# Altium schematic sheet Trinamic Driver Schematic.SchDoc
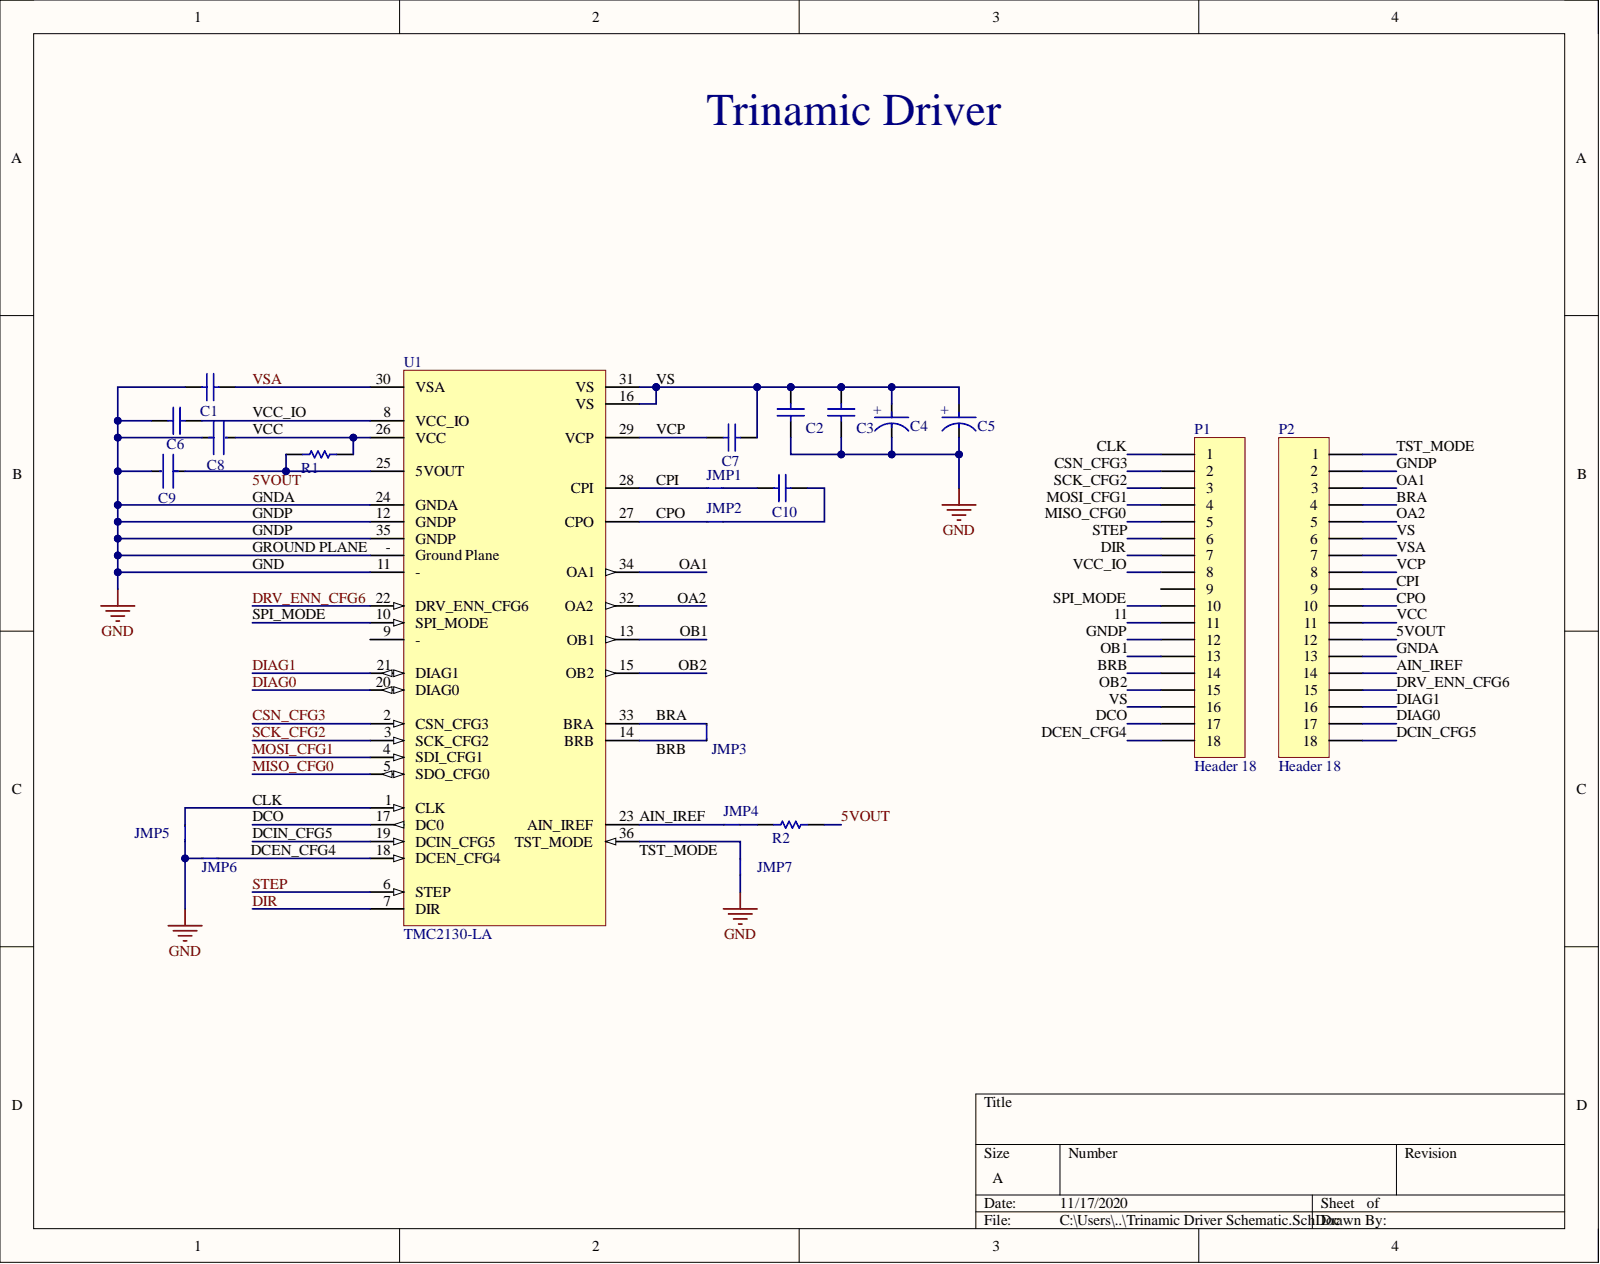
[diagram p0: Trinamic Driver Schematic.SchDoc]

## Parts
C1  CGA3E2X7R1H104K080AA  [CAPC1608X90X20LL10]  (value not drawn)
C2  CGA3E2X7R1H104K080AA  [CAPC1608X90X20LL10]  (value not drawn)
C3  C1210C476M4PACTU  [CAPC3225X270X50LL20T25]  (value not drawn)
C4  EEE-FK1H101P  [FP-EEE-FK1H101P-MFG]  (value not drawn)
C5  EEE-FK1H101P  [FP-EEE-FK1H101P-MFG]  (value not drawn)
C6  CGA3E2X7R1H104K080AA  [CAPC1608X90X20LL10]  (value not drawn)
C7  CGA3E2X7R1H104K080AA  [CAPC1608X90X20LL10]  (value not drawn)
C8  C0603C474K4RACTU  [FP-C0603C-CF-MFG]  (value not drawn)
C9  C0603C475K9PACTU  [FP-C0603-CG-MFG]  (value not drawn)
C10  CC0603KRX7R9BB223  [CAPC1608X90X40ML10T20]  (value not drawn)
JMP1  Solder Jump  [Solder Jump]  (value not drawn)
JMP2  Solder Jump  [Solder Jump]  (value not drawn)
JMP3  Solder Jump  [Solder Jump]  (value not drawn)
JMP4  Solder Jump  [Solder Jump]  (value not drawn)
JMP5  Solder Jump  [Solder Jump]  (value not drawn)
JMP6  Solder Jump  [Solder Jump]  (value not drawn)
JMP7  Solder Jump  [Solder Jump]  (value not drawn)
P1  Header 18  [HDR1X18]
P2  Header 18  [HDR1X18]
R1  CRCW06033R30JNEA  [RESC1609X50X30ML10T20]  (value not drawn)
R2  ERJ3EKF1002V  [RESC1609X55X30LL15T15]  (value not drawn)
U1  TMC2130-LA  [QFN-36]

## Nets
5VOUT: C9.1 P2.12 R1.1 R2.2 U1.25(5VOUT)
11: P1.11
AIN_IREF: JMP4.1 P2.14 U1.23(AIN_IREF)
BRA: JMP3.2 P2.4 U1.33(BRA)
BRB: JMP3.1 P1.14 U1.14(BRB)
CLK: JMP5.2 P1.1 U1.1(CLK)
CPI: JMP1.1 P2.9 U1.28(CPI)
CPO: JMP2.1 P2.10 U1.27(CPO)
CSN_CFG3: P1.2 U1.2(CSN_CFG3)
DCEN_CFG4: JMP6.1 P1.18 U1.18(DCEN_CFG4)
DCIN_CFG5: P2.18 U1.19(DCIN_CFG5)
DCO: P1.17 U1.17(DC0)
DIAG0: P2.17 U1.20(DIAG0)
DIAG1: P2.16 U1.21(DIAG1)
DIR: P1.7 U1.7(DIR)
DRV_ENN_CFG6: P2.15 U1.22(DRV_ENN_CFG6)
GND: C1.1 C2.1 C3.1 C4.1 C5.1 C6.1 C8.1 C9.2 JMP5.1 JMP6.2 JMP7.2 P1.12 P2.2 P2.13 U1.11(-) U1.12(GNDP) U1.24(GNDA) U1.35(GNDP) U1.-(Ground_Plane)
MISO_CFG0: P1.5 U1.5(SDO_CFG0)
MOSI_CFG1: P1.4 U1.4(SDI_CFG1)
OA1: P2.3 U1.34(OA1)
OA2: P2.5 U1.32(OA2)
OB1: P1.13 U1.13(OB1)
OB2: P1.15 U1.15(OB2)
SCK_CFG2: P1.3 U1.3(SCK_CFG2)
SPI_MODE: P1.10 U1.10(SPI_MODE)
STEP: P1.6 U1.6(STEP)
TST_MODE: JMP7.1 P2.1 U1.36(TST_MODE)
VCC: C8.2 P2.11 R1.2 U1.26(VCC)
VCC_IO: C6.2 P1.8 U1.8(VCC_IO)
VCP: C7.2 P2.8 U1.29(VCP)
VS: C2.2 C3.2 C4.2 C5.2 C7.1 P1.16 P2.6 U1.16(VS) U1.31(VS)
VSA: C1.2 P2.7 U1.30(VSA)
NetC10_1: C10.1 JMP1.2
NetC10_2: C10.2 JMP2.2
NetJMP4_2: JMP4.2 R2.1

Not connected: P1 9; U1 9(-)

## Library data of the parts
C1 C2 C6 C7 = CMP-2000-07546-1: Chip Capacitor, 0.1 uF, +/- 10%, 50 V, -55 to 125 degC, 0603 (1608 Metric), RoHS, Tape and Reel; Manufacturer: TDK; Capacitance: 100nF; Dielectric: X7R; Voltage: 50V; Case Code (Imperial): 0603; Case Code (Metric): 1608; Case/Package: 0603; Component Kind: Standard; Composition: Ceramic; Datasheet URL: https://product.tdk.com/info/en/catalog/datasheets/mlcc_automotive_general_en.pdf; Datasheet Version: 12/2014; Depth: 0.8mm; Height: 0.8mm; Lead Free: LeadFree; Length: 1.6mm; Library Name: Altium Content Vault; Manufacturer URL: http://www.tdk.com/; Max Operating Temperature: 125degC; Min Operating Temperature: -55degC; Mount: SurfaceMount; Mounting Technology: SurfaceMount; Package Description: 2-Pin Surface Mount Device, Body 1.6 x 0.8 mm; Package Quantity: 1; Package Reference: 603; Packaging: TapeandReel; Radiation Hardening: No; REACH SVHC: NoSVHC; RoHSCompliant: True; Termination: SMD/SMT; Thickness: 0.035inch; Tolerance: 10%; Voltage Rating: 50V; Voltage Rating (DC): 50V; Weight: 7.1E-05oz; Width: 0.8mm
C3 = CMP-2000-06020-1: Chip Capacitor, 47 uF, +/- 20%, 16 V, -55 to 85 degC, 1210 (3225 Metric), RoHS, Tape and Reel; Manufacturer: Kemet; Capacitance: 47µF; Catalog Drawings: ACTU_1210; Online Catalog: X5RDielectric(CommercialGrade); Other Names: 399-5514-6; Product Training Modules: CapacitorBasics-TypicalUsesforCapacitors; Temperature Coefficient: X5R; Voltage - Rated: 16V; Applications: GeneralPurpose; Category: Capacitors; Component Kind: Standard; Datasheet URL: http://www.datasheets360.com/pdf/-8690541728068054270; Datasheet Version: 05/2013; Family: CeramicCapacitors; Features: -; Height - Seated (Max): -; Lead Spacing: -; Lead Style: -; Library Name: Altium Content Vault; Manufacturer URL: http://www.kemet.com/; Mounting Technology: SurfaceMount; Mounting Type: SurfaceMount,MLCC; Operating Temperature: -55°C~85°C; Package / Case: 1210(3225Metric); Package Description: 2-Pin Surface Mount Device, Body 3.2 x 2.5 mm; Package Reference: 1210; Packaging: Digi-Reel®; Ratings: -; Series: C; Size / Dimension: 0.126"Lx0.098"W(3.20mmx2.50mm); Standard Package: 1; Thickness (Max): 0.110"(2.80mm); Tolerance: ±20%
C4 C5 = CMP-05427-000005-1: CAP ALUM 100UF 20% 50V SMD; Manufacturer: Panasonic Electronic Components; Automotive Grade: Grade 2; Dissipation Factor: 10%; Esr: 0.34Ω; Leakage Current: 50uA; Life: 2000Hours; Material: Aluminum; Ripple Current: 350mA; Automotive: Yes; Capacitance: 100uF; Category: Cap; Component Kind: Standard; ComponentLink2Description: Datasheet; ComponentLink2URL: https://industrial.panasonic.com/cdbs/www-data/pdf/RDE0000/ABA0000C1181.pdf; Device Class L1: Passive Components; Device Class L2: Capacitors; Device Class L3: Aluminum Electrolytic Capacitors; Digikey Description: CAP ALUM 100UF 20% 50V SMD; Height: 10.5mm; Lead Free: Yes; Library Name: Altium Content Vault; Manufacturer Part Number: EEE-FK1H101P; Mouser Description: Cap Aluminum Lytic 100uF 50V 20% (8 X 10.2mm) SMD 350mA 2000h 105C Automotive T/R; Octopart: https://octopart.com/eeefk1h101p-panasonic-4642841; Package: 0; RoHS: TRUE; Temperature: -55°C to +105°C; Temperature Range High: +105°C; Temperature Range Low: -55°C; Tolerance: 20%; Value: 100uF; Voltage Rating: 50V
C8 = CMP-03020-024061-1: CAP CER 0.47UF 16V X7R 0603; Manufacturer: KEMET; Automotive Grade: Grade 1; Capacitance: 0.47 μF; Material: Ceramic; Temperature Coefficient: 30ppm/°C; Tolerance: 0.1; Value: 0.47 μF; Automotive: Yes; Category: Cap; Component Kind: Standard; ComponentLink2Description: Datasheet; ComponentLink2URL: https://api.kemet.com/component-edge/download/datasheet/C0603C100J5GACAUTO.pdf; Device Class L1: Passive Components; Device Class L2: Capacitors; Device Class L3: Ceramic Capacitors; Digikey Description: CAP CER 0.47UF 16V X7R 0603; Height: 0.87mm; Lead Free: Yes; Library Name: Altium Content Vault; Manufacturer Part Number: C0603C474K4RACTU; Mouser Description: 0603 C 470nF Ceramic Multilayer Capacitor, 16 V, +125degC, X7R Dielec, +/-10%; Octopart: https://octopart.com/c0603c474k4ractu-kemet-109683; Package: C0603C-CF; RoHS: TRUE; Temperature: -55°C to +125°C; Temperature Characteristic: X7R; Temperature Range High: +125°C; Temperature Range Low: -55°C; Voltage Rating: 16 V
C9 = CMP-03020-024641-1: CAP CER 4.7UF 6.3V X5R 0603; Manufacturer: Kemet; Material: Ceramic; Temperature Coefficient: 15%; Tolerance: 10%; Automotive: No; Capacitance: 4.7uF; Category: Cap; Component Kind: Standard; ComponentLink2Description: Datasheet; ComponentLink2URL: https://content.kemet.com/datasheets/KEM_C1006_X5R_SMD.pdf; Device Class L1: Passive Components; Device Class L2: Capacitors; Device Class L3: Ceramic Capacitors; Digikey Description: CAP CER 4.7UF 6.3V X5R 0603; Height: 0.9mm; Ipc Land Pattern Name: CAPC16080X80; Lead Free: Yes; Library Name: Altium Content Vault; Manufacturer Part Number: C0603C475K9PACTU; Mouser Description: Multilayer Ceramic Capacitors MLCC - SMD/SMT 6.3volts 4.7uF X5R 10%; Octopart: https://octopart.com/c0603c475k9pactu-kemet-104242; Package: C0603-CG; RoHS: TRUE; Temperature: -55°C to +85°C; Temperature Characteristic: X5R; Temperature Range High: +85°C; Temperature Range Low: -55°C; Value: 4.7uF; Voltage Rating: 6.3V
C10 = CMP-2100-03602-1: Chip Capacitor, 22 nF, +/- 10%, 50 V, -55 to 125 degC, 0603 (1608 Metric), RoHS, Tape and Reel; Manufacturer: Yageo; Capacitance: 22nF; Dielectric: X7R; Dielectric Material: Ceramic; Insulation Resistance: 100GR; Voltage Rating: 50V; Case Code (Imperial): 0603; Case Code (Metric): 1608; Case/Package: 0603; Component Kind: Standard; Composition: Ceramic; Datasheet URL: http://www.yageo.com/documents/recent/UPY-GPHC_X7R_6.3V-to-50V_15.pdf; Datasheet Version: Rev. 15, 10/2016; Depth: 0.8mm; Height: 0.8mm; Lead Free: LeadFree; Length: 1.6mm; Library Name: Altium Content Vault; Manufacturer URL: http://www.yageo.com/; Max Operating Temperature: 125degC; Min Operating Temperature: -55degC; Mount: SurfaceMount; Number of Pins: 2; Package Description: 2-Pin Surface Mount Device, Body 1.6 x 0.8 mm; Package Quantity: 1; Package Reference: 0603; Packaging: TapeandReel; Radiation Hardening: No; REACH SVHC: NoSVHC; RoHSCompliant: True; Termination: SMD/SMT; Thickness: 0.035inch; Tolerance: 10%; Voltage Rating (DC): 50V; Width: 0.031inch
P1 P2 = Header 18: Header, 18-Pin; Component Kind: Standard; LatestRevisionDate: 17-Jul-2002; LatestRevisionNote: Re-released for DXP Platform.; Library Name: Miscellaneous Connectors.IntLib; Signal Integrity: Connector; Publisher: Altium Limited
R1 = CMP-2000-03328-1: Manufacturer: Vishay Dale; Component Kind: Standard; Library Name: Altium Content Vault; Part Number: CRCW06033R30JNEA
R2 = CMP-2000-07452-1: Chip Resistor, 10 KOhm, +/- 1%, 0.1 W, -55 to 155 degC, 0603 (1608 Metric), RoHS, Tape and Reel; Manufacturer: Panasonic; Case\/Package: 0603; Max Power Dissipation: 100mW; Resistance: 10KOhm; Temperature Coefficient: 100ppm\/degreesC; Voltage Rating: 75V; Case Code (Imperial): 0603; Case Code (Metric): 1608; Component Kind: Standard; Composition: ThickFilm; Contact Plating: Tin; Datasheet URL: https://industrial.panasonic.com/cdbs/www-data/pdf/RDA0000/AOA0000C304.pdf; Datasheet Version: 02/2017; Depth: 0.8mm; Height: 0.45mm; Lead Free: LeadFree; Length: 1.6mm; Library Name: Altium Content Vault; Manufacturer URL: http://www.panasonic.com/; Max Operating Temperature: 155degC; Military Standard: Not; Min Operating Temperature: -55degC; Mount: SurfaceMount; Mounting Technology: SurfaceMount; Number of Pins: 2; Number of Terminations: 2; Package Description: 2-Pin Surface Mount Device, Body 1.6 x 0.85 mm; Package Quantity: 1; Package Reference: 603; Packaging: TapeandReel; Power Rating: 100mW; Radiation Hardening: No; REACH SVHC: NoSVHC; RoHSCompliant: true; Termination: SMD/SMT; Tolerance: 1%; Voltage Rating (DC): 75V; Width: 0.031inch
U1 = TMC2130-LA: TMC2130-LA Trinamic Driver QFN-36; Component Kind: Standard; Library Name: SDP21_Symbols.SchLib
JMP1-JMP7 = Solder Jump: Component Kind: Standard; Library Name: SDP21_Symbols.SchLib
